# Altium schematic sheet BMB_Microcontroller.SchDoc(BMB_Microcontroller)
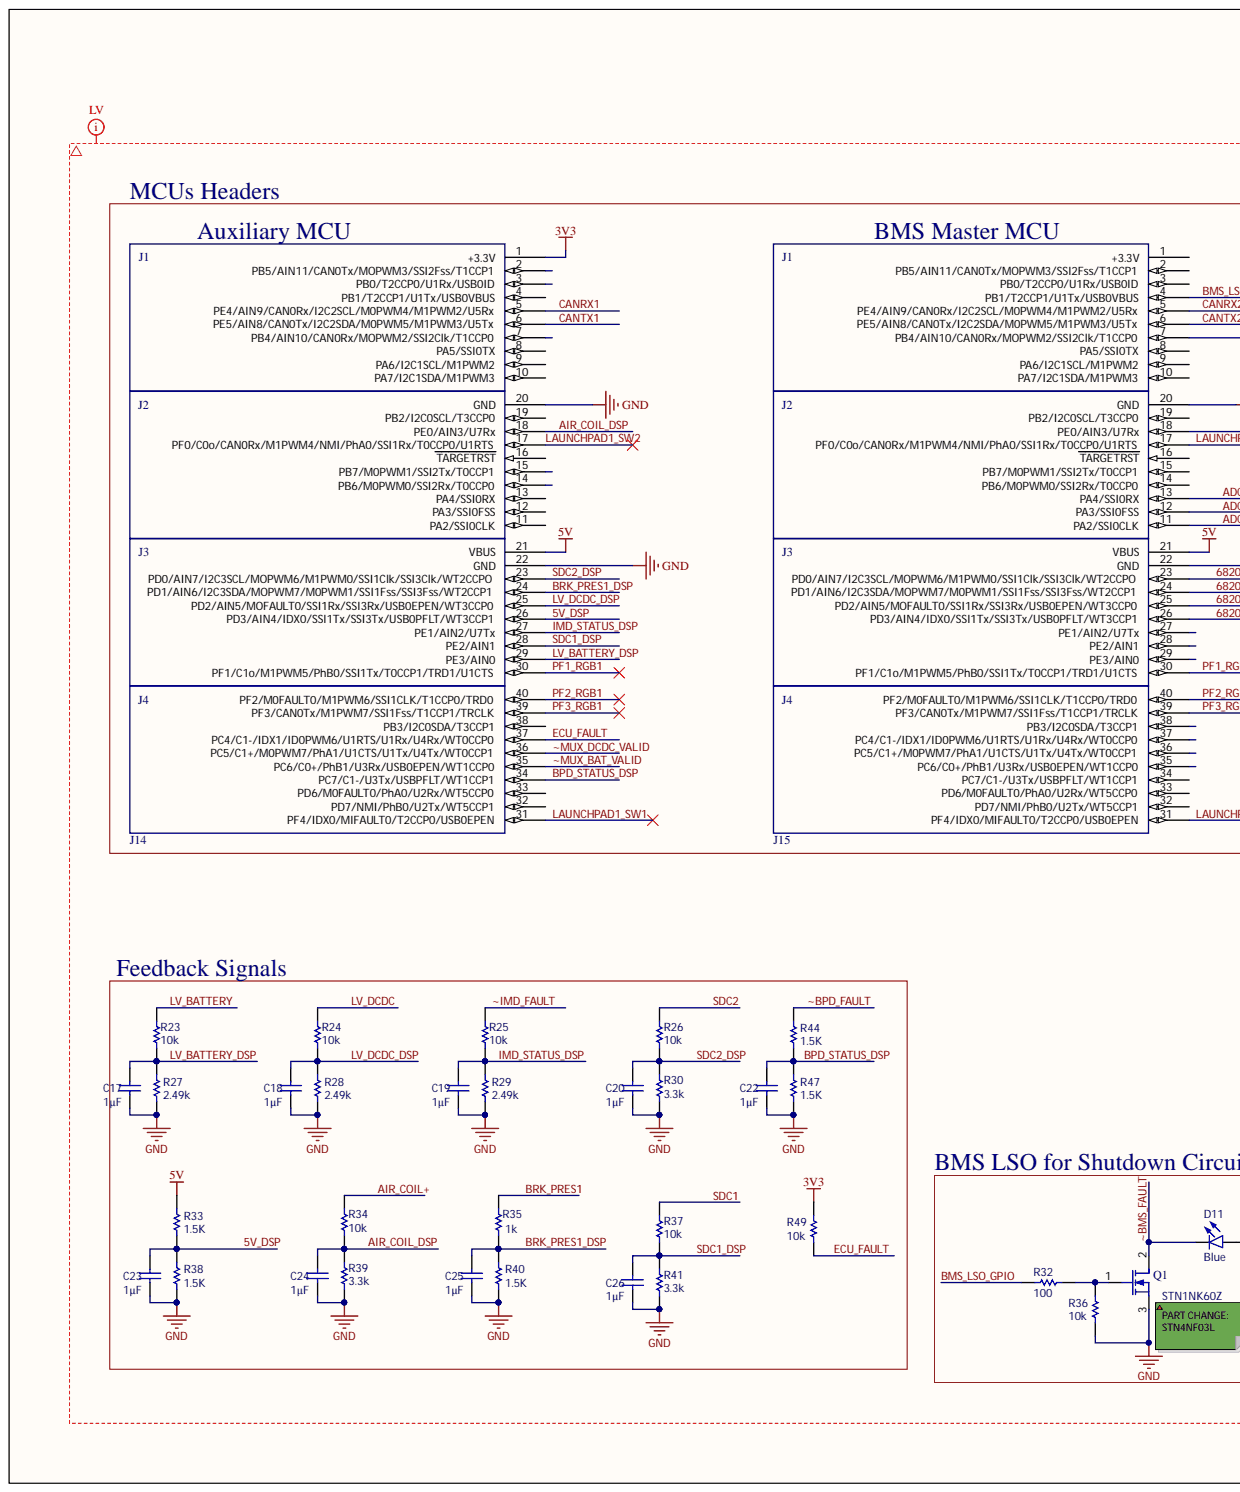
[diagram p0: BMB_Microcontroller.SchDoc, left]
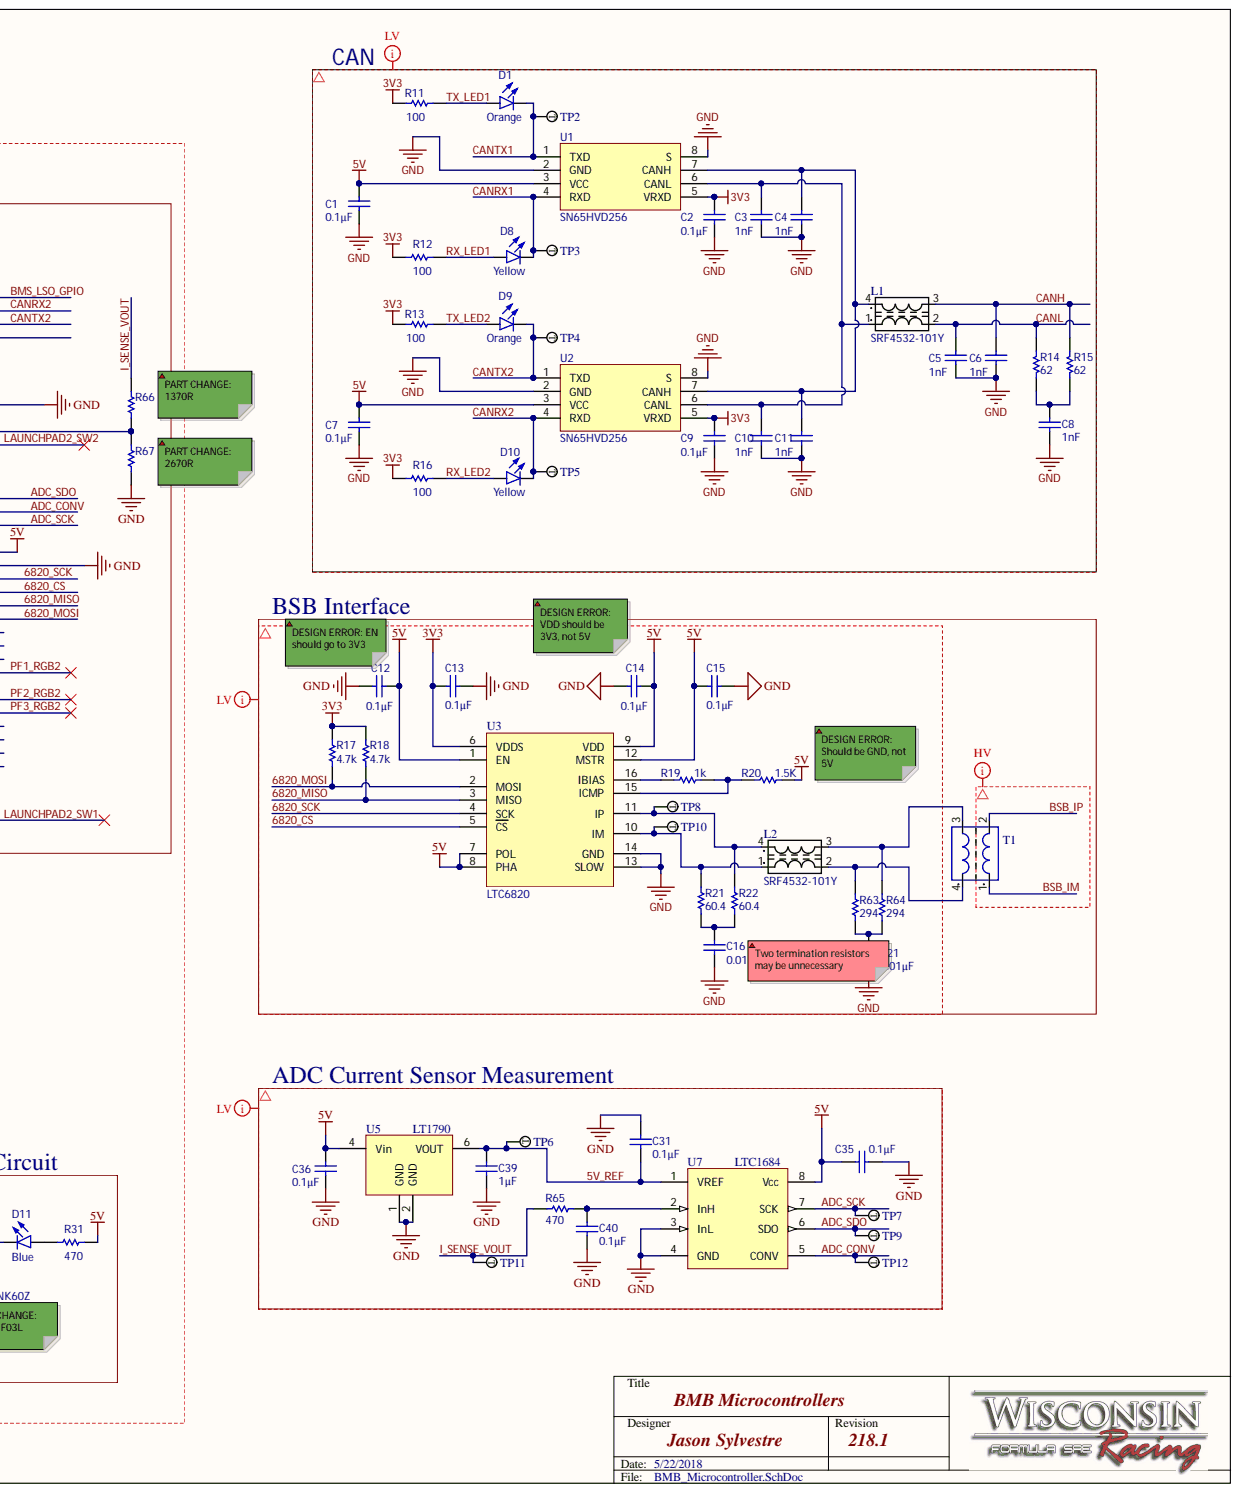
[diagram p1: BMB_Microcontroller.SchDoc, right]

## Parts
C1  Capacitance: 0.1µF  [1608[0603]]  (hidden comment: CAP)
C2  Capacitance: 0.1µF  [1608[0603]]  (hidden comment: CAP)
C3  Capacitance: 1nF  [1608[0603]]  (hidden comment: CAP)
C4  Capacitance: 1nF  [1608[0603]]  (hidden comment: CAP)
C5  Capacitance: 1nF  [1608[0603]]  (hidden comment: CAP)
C6  Capacitance: 1nF  [1608[0603]]  (hidden comment: CAP)
C7  Capacitance: 0.1µF  [1608[0603]]  (hidden comment: CAP)
C8  Capacitance: 1nF  [1608[0603]]  (hidden comment: CAP)
C9  Capacitance: 0.1µF  [1608[0603]]  (hidden comment: CAP)
C10  Capacitance: 1nF  [1608[0603]]  (hidden comment: CAP)
C11  Capacitance: 1nF  [1608[0603]]  (hidden comment: CAP)
C12  Capacitance: 0.1µF  [1608[0603]]  (hidden comment: CAP)
C13  Capacitance: 0.1µF  [1608[0603]]  (hidden comment: CAP)
C14  Capacitance: 0.1µF  [1608[0603]]  (hidden comment: CAP)
C15  Capacitance: 0.1µF  [1608[0603]]  (hidden comment: CAP)
C16  Capacitance: 0.01µF  [1608[0603]]  (hidden comment: Cap)
C17  Capacitance: 1µF  [1608[0603]]  (hidden comment: Cap)
C18  Capacitance: 1µF  [1608[0603]]  (hidden comment: Cap)
C19  Capacitance: 1µF  [1608[0603]]  (hidden comment: Cap)
C20  Capacitance: 1µF  [1608[0603]]  (hidden comment: Cap)
C21  Capacitance: 0.01µF  [1608[0603]]  (hidden comment: Cap)
C22  Capacitance: 1µF  [1608[0603]]  (hidden comment: Cap)
C23  Capacitance: 1µF  [1608[0603]]  (hidden comment: Cap)
C24  Capacitance: 1µF  [1608[0603]]  (hidden comment: Cap)
C25  Capacitance: 1µF  [1608[0603]]  (hidden comment: Cap)
C26  Capacitance: 1µF  [1608[0603]]  (hidden comment: Cap)
C31  Capacitance: 0.1µF  [1608[0603]]  (hidden comment: CAP)
C35  Capacitance: 0.1µF  [1608[0603]]  (hidden comment: CAP)
C36  Capacitance: 0.1µF  [1608[0603]]  (hidden comment: CAP)
C39  Capacitance: 1µF  [1608[0603]]  (hidden comment: Cap)
C40  Capacitance: 0.1µF  [1608[0603]]  (hidden comment: CAP)
D1  Orange  [1608 LED]
D8  Yellow  [1608 LED]
D9  Orange  [1608 LED]
D10  Yellow  [1608 LED]
D11  Blue  [1608 LED]
J14  TM4C123G_Headers  [TIVA_HEADERS]  (value not drawn)
J15  TM4C123G_Headers  [TIVA_HEADERS]  (value not drawn)
L1  Manufacturer Part Number: SRF4532-101Y  [SRF4532]  (hidden comment: Common Mode Choke)
L2  Manufacturer Part Number: SRF4532-101Y  [SRF4532]  (hidden comment: Common Mode Choke)
Q1  Manufacturer Part Number: STN1NK60Z  [SOT223-4L]  (hidden comment: MOSFET-N)
R11  Res  [RESC1608N]  (value not drawn)
R12  Res  [RESC1608N]  (value not drawn)
R13  Res  [RESC1608N]  (value not drawn)
R14  Res  [RESC3216N]  (value not drawn)
R15  Res  [RESC3216N]  (value not drawn)
R16  Res  [RESC1608N]  (value not drawn)
R17  Resistance: 4.7k  [RESC1608N]  (hidden comment: Res)
R18  Resistance: 4.7k  [RESC1608N]  (hidden comment: Res)
R19  Resistance (Ohms): 1k  [RESC1608N]
R20  Resistance: 1.5K  [RESC1608N]
R21  Resistance: 60.4  [RESC1608N]  (hidden comment: Res)
R22  Resistance: 60.4  [RESC1608N]  (hidden comment: Res)
R23  Resistance: 10k  [RESC1608N]  (hidden comment: Res1)
R24  Resistance: 10k  [RESC1608N]  (hidden comment: Res1)
R25  Resistance: 10k  [RESC1608N]  (hidden comment: Res1)
R26  Resistance: 10k  [RESC1608N]  (hidden comment: Res1)
R27  Resistance: 2.49k  [RESC1608N]  (hidden comment: Res1)
R28  Resistance: 2.49k  [RESC1608N]  (hidden comment: Res1)
R29  Resistance: 2.49k  [RESC1608N]  (hidden comment: Res1)
R30  Resistance: 3.3k  [RESC1608N]  (hidden comment: Res1)
R31  Res1  [RESC1608N]  (value not drawn)
R32  Res1  [RESC1608N]  (value not drawn)
R33  Resistance: 1.5K  [RESC1608N]  (hidden comment: Res1)
R34  Resistance: 10k  [RESC1608N]  (hidden comment: Res1)
R35  Resistance (Ohms): 1k  [RESC1608N]
R36  Resistance: 10k  [RESC1608N]  (hidden comment: Res1)
R37  Resistance: 10k  [RESC1608N]  (hidden comment: Res1)
R38  Resistance: 1.5K  [RESC1608N]  (hidden comment: Res1)
R39  Resistance: 3.3k  [RESC1608N]  (hidden comment: Res1)
R40  Resistance: 1.5K  [RESC1608N]  (hidden comment: Res1)
R41  Resistance: 3.3k  [RESC1608N]  (hidden comment: Res1)
R44  Resistance: 1.5K  [RESC1608N]  (hidden comment: Res1)
R47  Resistance: 1.5K  [RESC1608N]  (hidden comment: Res1)
R49  Resistance: 10k  [RESC1608N]  (hidden comment: Res1)
R63  Res  [RESC1608N]  (value not drawn)
R64  Res  [RESC1608N]  (value not drawn)
R65  Res1  [RESC1608N]  (value not drawn)
R66  Res  [RESC1608N]  (value not drawn)
R67  Res  [RESC1608N]  (value not drawn)
T1  Pulse Transformer  [ESMIT-4180/A]  (value not drawn)
TP2  Test Point  (value not drawn)
TP3  Test Point  (value not drawn)
TP4  Test Point  (value not drawn)
TP5  Test Point  (value not drawn)
TP6  Test Point  (value not drawn)
TP7  Test Point  (value not drawn)
TP8  Test Point  (value not drawn)
TP9  Test Point  (value not drawn)
TP10  Test Point  (value not drawn)
TP11  Test Point  (value not drawn)
TP12  Test Point  (value not drawn)
U1  SN65HVD256  [SO8_N]
U2  SN65HVD256  [SO8_N]
U3  LTC6820  [MSOP-16_MS]
U5  LT1790  Output Voltage: 5V  [SOT23-6_N]
U7  LTC1684  [SO8_N]

## Nets
3V3: C2.1 C9.1 C13.2 J14.1(+3.3V) R11.1 R12.1 R13.1 R16.1 R17.2 R18.2 R49.2 U1.5(VRXD) U2.5(VRXD) U3.6(VDDS)
5V: C1.1 C7.1 C12.2 C14.2 C15.2 C35.1 C36.2 J14.21(VBUS) J15.21(VBUS) R20.2 R31.1 R33.2 U1.3(VCC) U2.3(VCC) U3.1(EN) U3.7(POL) U3.8(PHA) U3.9(VDD) U3.12(MSTR) U5.4(Vin) U7.8(Vcc)
GND: C1.2 C2.2 C3.1 C4.1 C5.1 C6.1 C7.2 C8.2 C9.2 C10.1 C11.1 C12.1 C13.1 C14.1 C15.1 C16.2 C17.2 C18.2 C19.2 C20.2 C21.2 C22.2 C23.2 C24.2 C25.2 C26.2 C31.2 C35.2 C36.1 C39.1 C40.1 J14.20(GND) J14.22(GND) J15.20(GND) J15.22(GND) Q1.3 R27.1 R28.1 R29.1 R30.1 R36.1 R38.1 R39.1 R40.1 R41.1 R47.1 R67.1 U1.2(GND) U1.8(S) U2.2(GND) U2.8(S) U3.13(SLOW) U3.14(GND) U5.1(GND) U5.2(GND) U7.3(InL) U7.4(GND)
5V_DSP: C23.1 J14.26(PD3/AIN4/IDX0/SSI1Tx/SSI3Tx/USB0PFLT/WT3CCP1) R33.1 R38.2
5V_REF: C31.1 C39.2 TP6.1 U5.6(VOUT) U7.1(VREF)
6820_CS: J15.24(PD1/AIN6/I2C3SDA/MOPWM7/M0PWM1/SSI1Fss/SSI3Fss/WT2CCP1) U3.5(CS)
6820_MISO: J15.25(PD2/AIN5/MOFAULT0/SSI1Rx/SSI3Rx/USB0EPEN/WT3CCP0) R18.1 U3.3(MISO)
6820_MOSI: J15.26(PD3/AIN4/IDX0/SSI1Tx/SSI3Tx/USB0PFLT/WT3CCP1) R17.1 U3.2(MOSI)
6820_SCK: J15.23(PD0/AIN7/I2C3SCL/MOPWM6/M1PWM0/SSI1Clk/SSI3Clk/WT2CCPO) U3.4(SCK)
ADC_CONV: J15.12(PA3/SSI0FSS) TP12.1 U7.5(CONV)
ADC_SCK: J15.11(PA2/SSI0CLK) TP7.1 U7.7(SCK)
ADC_SDO: J15.13(PA4/SSI0RX) TP9.1 U7.6(SDO)
AIR_COIL+: R34.2
AIR_COIL_DSP: C24.1 J14.18(PE0/AIN3/U7Rx) R34.1 R39.2
BMS_LSO_GPIO: J15.4(PB1/T2CCP1/U1Tx/USB0VBUS) R32.2
BPD_STATUS_DSP: C22.1 J14.34(PC7/C1-/U3Tx/USBPFLT/WT1CCP1) R44.1 R47.2
BRK_PRES1: R35.2
BRK_PRES1_DSP: C25.1 J14.24(PD1/AIN6/I2C3SDA/MOPWM7/M0PWM1/SSI1Fss/SSI3Fss/WT2CCP1) R35.1 R40.2
BSB_IM: T1.1
BSB_IP: T1.2
CANH: C6.2 L1.3 R15.1
CANL: C5.2 L1.2 R14.1
CANRX1: D8.2 J14.5(PE4/AIN9/CAN0Rx/I2C2SCL/M0PWM4/M1PWM2/U5Rx) TP3.1 U1.4(RXD)
CANRX2: D10.2 J15.5(PE4/AIN9/CAN0Rx/I2C2SCL/M0PWM4/M1PWM2/U5Rx) TP5.1 U2.4(RXD)
CANTX1: D1.2 J14.6(PE5/AIN8/CAN0Tx/I2C2SDA/M0PWM5/M1PWM3/U5Tx) TP2.1 U1.1(TXD)
CANTX2: D9.2 J15.6(PE5/AIN8/CAN0Tx/I2C2SDA/M0PWM5/M1PWM3/U5Tx) TP4.1 U2.1(TXD)
ECU_FAULT: J14.37(PC4/C1-/IDX1/ID0PWM6/U1RTS/U1Rx/U4Rx/WT0CCP0) R49.1
I_SENSE_VOUT: R65.2 R66.2 TP11.1
IMD_STATUS_DSP: C19.1 J14.27(PE1/AIN2/U7Tx) R25.1 R29.2
LAUNCHPAD1_SW1: J14.31(PF4/IDX0/MIFAULT0/T2CCP0/USB0EPEN)
LAUNCHPAD1_SW2: J14.17(PF0/C0o/CAN0Rx/M1PWM4/NMI/PhA0/SSI1Rx/T0CCP0/U1RTS)
LAUNCHPAD2_SW1: J15.31(PF4/IDX0/MIFAULT0/T2CCP0/USB0EPEN)
LAUNCHPAD2_SW2: J15.17(PF0/C0o/CAN0Rx/M1PWM4/NMI/PhA0/SSI1Rx/T0CCP0/U1RTS)
LV_BATTERY: R23.2
LV_BATTERY_DSP: C17.1 J14.29(PE3/AIN0) R23.1 R27.2
LV_DCDC: R24.2
LV_DCDC_DSP: C18.1 J14.25(PD2/AIN5/MOFAULT0/SSI1Rx/SSI3Rx/USB0EPEN/WT3CCP0) R24.1 R28.2
PF1_RGB1: J14.30(PF1/C1o/M1PWM5/PhB0/SSI1Tx/T0CCP1/TRD1/U1CTS)
PF1_RGB2: J15.30(PF1/C1o/M1PWM5/PhB0/SSI1Tx/T0CCP1/TRD1/U1CTS)
PF2_RGB1: J14.40(PF2/M0FAULT0/M1PWM6/SSI1CLK/T1CCP0/TRD0)
PF2_RGB2: J15.40(PF2/M0FAULT0/M1PWM6/SSI1CLK/T1CCP0/TRD0)
PF3_RGB1: J14.39(PF3/CAN0Tx/M1PWM7/SSI1Fss/T1CCP1/TRCLK)
PF3_RGB2: J15.39(PF3/CAN0Tx/M1PWM7/SSI1Fss/T1CCP1/TRCLK)
RX_LED1: D8.1 R12.2
RX_LED2: D10.1 R16.2
SDC1: R37.2
SDC1_DSP: C26.1 J14.28(PE2/AIN1) R37.1 R41.2
SDC2: R26.2
SDC2_DSP: C20.1 J14.23(PD0/AIN7/I2C3SCL/MOPWM6/M1PWM0/SSI1Clk/SSI3Clk/WT2CCPO) R26.1 R30.2
TX_LED1: D1.1 R11.2
TX_LED2: D9.1 R13.2
~BMS_FAULT: D11.2 Q1.2
~BPD_FAULT: R44.2
~IMD_FAULT: R25.2
~MUX_BAT_VALID: J14.35(PC6/C0+/PhB1/U3Rx/USB0EPEN/WT1CCP0)
~MUX_DCDC_VALID: J14.36(PC5/C1+/M0PWM7/PhA1/U1CTS/U1Tx/U4Tx/WT0CCP1)
NetC3_2: C3.2 C10.2 L1.1 U1.6(CANL) U2.6(CANL)
NetC4_2: C4.2 C11.2 L1.4 U1.7(CANH) U2.7(CANH)
NetC8_1: C8.1 R14.2 R15.2
NetC16_1: C16.1 R21.1 R22.1
NetC21_1: C21.1 R63.1 R64.1
NetC40_2: C40.2 R65.1 U7.2(InH)
NetD11_1: D11.1 R31.2
NetJ15_18: J15.18(PE0/AIN3/U7Rx) R66.1 R67.2
NetL2_1: L2.1 R21.2 TP10.1 U3.10(IM)
NetL2_2: L2.2 R63.2 T1.4
NetL2_3: L2.3 R64.2 T1.3
NetL2_4: L2.4 R22.2 TP8.1 U3.11(IP)
NetQ1_1: Q1.1 R32.1 R36.2
NetR19_1: R19.1 U3.16(IBIAS)
NetR19_2: R19.2 R20.1 U3.15(ICMP)
N00074:
N00075:
N00076:
N00077:
N00078:

Not connected: J14 2(PB5/AIN11/CAN0Tx/MOPWM3/SSI2Fss/T1CCP1) 3(PB0/T2CCP0/U1Rx/USB0ID) 4(PB1/T2CCP1/U1Tx/USB0VBUS) 7(PB4/AIN10/CAN0Rx/MOPWM2/SSI2Clk/T1CCP0) 8(PA5/SSI0TX) 9(PA6/I2C1SCL/M1PWM2) 10(PA7/I2C1SDA/M1PWM3) 11(PA2/SSI0CLK) 12(PA3/SSI0FSS) 13(PA4/SSI0RX) 14(PB6/M0PWM0/SSI2Rx/T0CCP0) 15(PB7/M0PWM1/SSI2Tx/T0CCP1) 16(TARGETRST) 19(PB2/I2C0SCL/T3CCP0) 32(PD7/NMI/PhB0/U2Tx/WT5CCP1) 33(PD6/M0FAULT0/PhA0/U2Rx/WT5CCP0) 38(PB3/I2C0SDA/T3CCP1); J15 1(+3.3V) 2(PB5/AIN11/CAN0Tx/MOPWM3/SSI2Fss/T1CCP1) 3(PB0/T2CCP0/U1Rx/USB0ID) 7(PB4/AIN10/CAN0Rx/MOPWM2/SSI2Clk/T1CCP0) 8(PA5/SSI0TX) 9(PA6/I2C1SCL/M1PWM2) 10(PA7/I2C1SDA/M1PWM3) 14(PB6/M0PWM0/SSI2Rx/T0CCP0) 15(PB7/M0PWM1/SSI2Tx/T0CCP1) 16(TARGETRST) 19(PB2/I2C0SCL/T3CCP0) 27(PE1/AIN2/U7Tx) 28(PE2/AIN1) 29(PE3/AIN0) 32(PD7/NMI/PhB0/U2Tx/WT5CCP1) 33(PD6/M0FAULT0/PhA0/U2Rx/WT5CCP0) 34(PC7/C1-/U3Tx/USBPFLT/WT1CCP1) 35(PC6/C0+/PhB1/U3Rx/USB0EPEN/WT1CCP0) 36(PC5/C1+/M0PWM7/PhA1/U1CTS/U1Tx/U4Tx/WT0CCP1) 37(PC4/C1-/IDX1/ID0PWM6/U1RTS/U1Rx/U4Rx/WT0CCP0) 38(PB3/I2C0SDA/T3CCP1)
